# Altium schematic sheet 05)PSD1.SchDoc(05)PSD1)
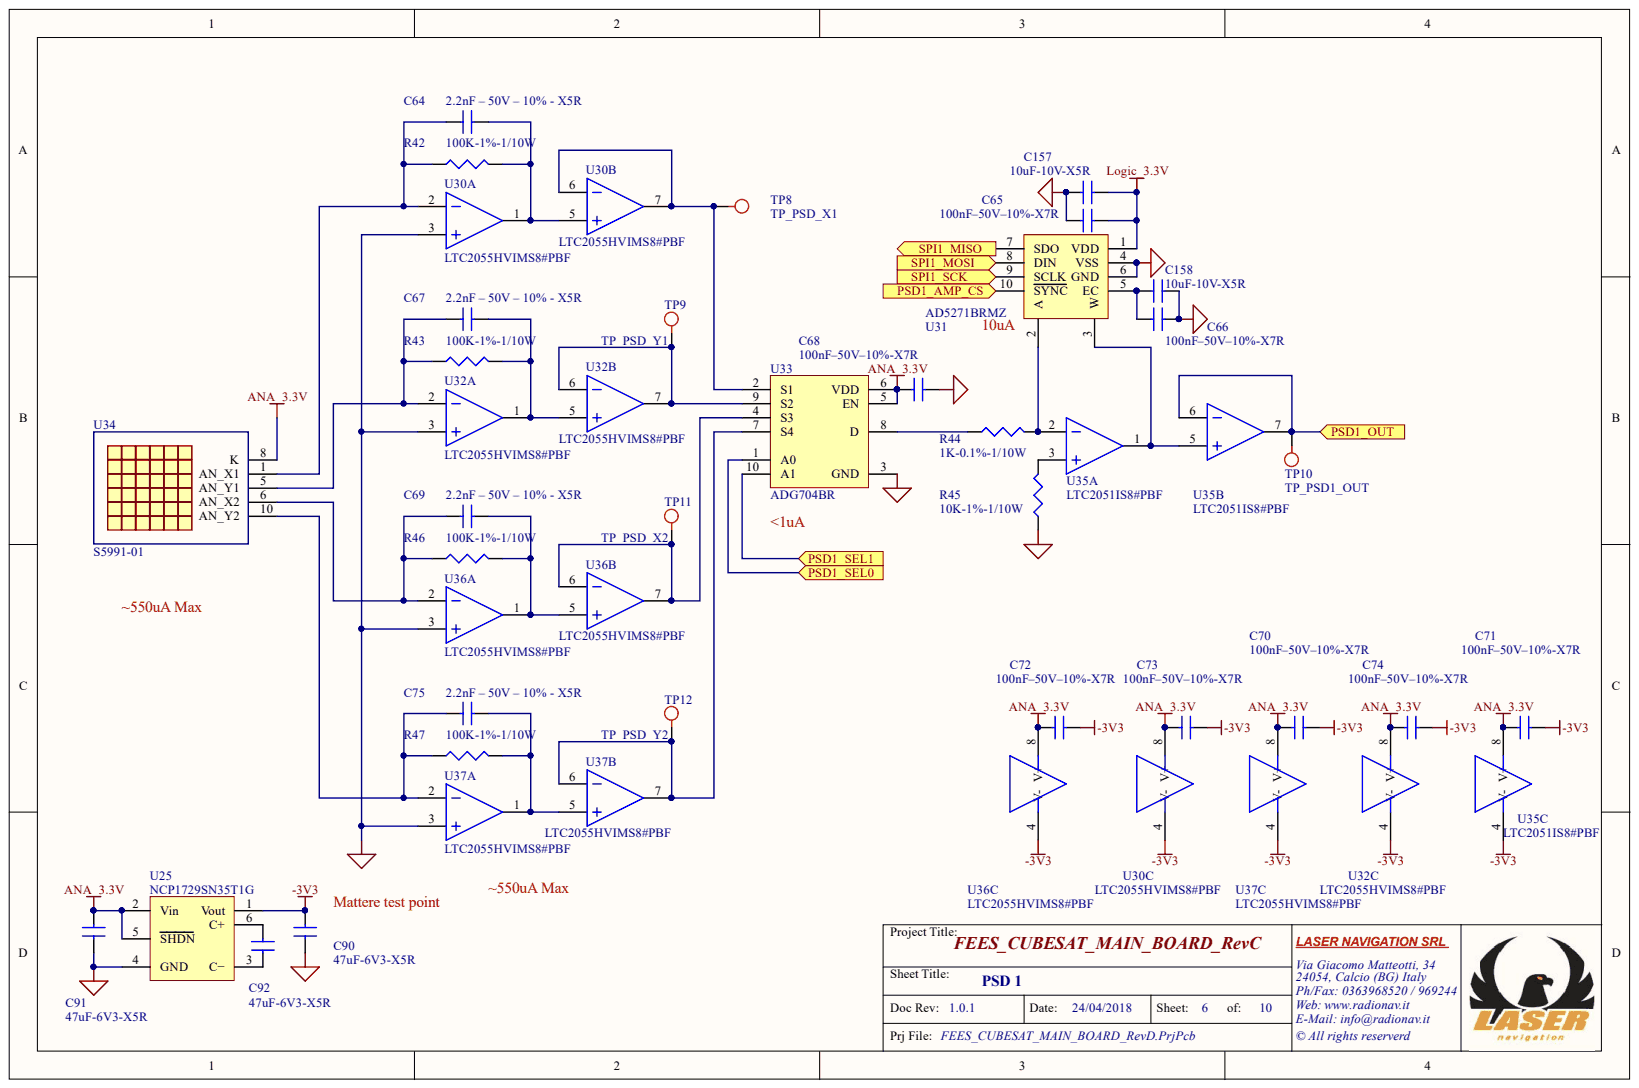
[diagram p0: 05)PSD1.SchDoc]

## Parts
C64  2.2nF – 50V – 10% - X5R  [0603_CAP]
C65  100nF–50V–10%-X7R  [0603_CAP]
C66  100nF–50V–10%-X7R  [0603_CAP]
C67  2.2nF – 50V – 10% - X5R  [0603_CAP]
C68  100nF–50V–10%-X7R  [0603_CAP]
C69  2.2nF – 50V – 10% - X5R  [0603_CAP]
C70  100nF–50V–10%-X7R  [0603_CAP]
C71  100nF–50V–10%-X7R  [0603_CAP]
C72  100nF–50V–10%-X7R  [0603_CAP]
C73  100nF–50V–10%-X7R  [0603_CAP]
C74  100nF–50V–10%-X7R  [0603_CAP]
C75  2.2nF – 50V – 10% - X5R  [0603_CAP]
C90  47uF-6V3-X5R  [0805_CAP]
C91  47uF-6V3-X5R  [0805_CAP]
C92  47uF-6V3-X5R  [0805_CAP]
C157  10uF-10V-X5R  [0805_CAP]
C158  10uF-10V-X5R  [0805_CAP]
R42  100K-1%-1/10W  [0603_RES]
R43  100K-1%-1/10W  [0603_RES]
R44  1K-0.1%-1/10W  [0603_RES]
R45  10K-1%-1/10W  [0603_RES]
R46  100K-1%-1/10W  [0603_RES]
R47  100K-1%-1/10W  [0603_RES]
TP8  TP_PSD_X1  [TP_SMD_PAD_1_5MM]
TP9  TP_PSD_Y1  [TP_SMD_PAD_1_5MM]
TP10  TP_PSD1_OUT  [TP_SMD_PAD_1_5MM]
TP11  TP_PSD_X2  [TP_SMD_PAD_1_5MM]
TP12  TP_PSD_Y2  [TP_SMD_PAD_1_5MM]
U25  NCP1729SN35T1G  [SOT23-6]
U30  LTC2055HVIMS8#PBF  [MSOP8]  (parts A,B,C)
U31  AD5271BRMZ  [MSOP10]
U32  LTC2055HVIMS8#PBF  [MSOP8]  (parts A,B,C)
U33  ADG704BR  [MSOP10_L]
U34  S5991-01  [S5991-01]
U35  LTC2051IS8#PBF  [MSOP8]  (parts A,B,C)
U36  LTC2055HVIMS8#PBF  [MSOP8]  (parts A,B,C)
U37  LTC2055HVIMS8#PBF  [MSOP8]  (parts A,B,C)

## Ports
PSD1_AMP_CS, PSD1_OUT, PSD1_SEL0, PSD1_SEL1, SPI1_MISO, SPI1_MOSI, SPI1_SCK

## Nets
-3V3: C70.2 C71.2 C72.2 C73.2 C74.2 C90.1 U25.1(Vout) U30.4(V-) U32.4(V-) U35.4(V-) U36.4(V-) U37.4(V-)
ANA_3.3V: C68.1 C70.1 C71.1 C72.1 C73.1 C74.1 C91.1 U25.2(Vin) U25.5(SHDN) U30.8(V+) U32.8(V+) U33.5(EN) U33.6(VDD) U34.8(K) U35.8(V+) U36.8(V+) U37.8(V+)
GND: C65.1 C66.1 C68.2 C90.2 C91.2 C157.2 C158.2 R45.1 U25.4(GND) U30.3 U31.4(VSS) U31.6(GND) U32.3 U33.3(GND) U36.3 U37.3
LOGIC_3.3V: C65.2 C157.1 U31.1(VDD)
PSD1_AMP_CS: U31.10(SYNC) ; port PSD1_AMP_CS
PSD1_OUT: TP10.1 U35.6 U35.7 ; port PSD1_OUT
PSD1_SEL0: U33.1(A0) ; port PSD1_SEL0
PSD1_SEL1: U33.10(A1) ; port PSD1_SEL1
SPI1_MISO: U31.7(SDO) ; port SPI1_MISO
SPI1_MOSI: U31.8(DIN) ; port SPI1_MOSI
SPI1_SCK: U31.9(SCLK) ; port SPI1_SCK
NetC64_1: C64.1 R42.1 U30.2 U34.1(AN_X1)
NetC64_2: C64.2 R42.2 U30.1 U30.5
NetC66_2: C66.2 C158.1 U31.5(EC)
NetC67_1: C67.1 R43.1 U32.2 U34.5(AN_Y1)
NetC67_2: C67.2 R43.2 U32.1 U32.5
NetC69_1: C69.1 R46.1 U34.6(AN_X2) U36.2
NetC69_2: C69.2 R46.2 U36.1 U36.5
NetC75_1: C75.1 R47.1 U34.10(AN_Y2) U37.2
NetC75_2: C75.2 R47.2 U37.1 U37.5
NetC92_1: C92.1 U25.6(C+)
NetC92_2: C92.2 U25.3(C−)
NetR44_1: R44.1 U33.8(D)
NetR44_2: R44.2 U31.2(A) U35.2
NetR45_2: R45.2 U35.3
NetTP8_1: TP8.1 U30.6 U30.7 U33.2(S1)
NetTP9_1: TP9.1 U32.6 U32.7 U33.9(S2)
NetTP11_1: TP11.1 U33.4(S3) U36.6 U36.7
NetTP12_1: TP12.1 U33.7(S4) U37.6 U37.7
NetU31_3: U31.3(W) U35.1 U35.5

## Library data of the parts
C64 C67 C69 C75 = CAP: Non polarized capacitor; 5.Mounting: Y; Component Kind: Standard; Library Name: User Sch Library.SchLib
C65 C66 C68 C70-C74 = CAP: Non polarized capacitor; Component Kind: Standard; Library Name: User Sch Library.SchLib
C90-C92 = CAP: Non polarized capacitor; 1.Part Number: JMK212BJ476MG-T; 2.Manufacturer: Taiyo Yuden; 3.Description: CAP CER 47UF 6.3V X5R 0805; 5.Mounting: Y; 4.Supplier: Digikey 587-1779-1-ND; Component Kind: Standard; Library Name: User Sch Library.SchLib
C157 C158 = CAP: Non polarized capacitor; 1.Part Number: LMK212BJ106KG-T; 2.Manufacturer: Taiyo Yuden; 3.Description: CAP CER 10UF 10V X5R 0805; 5.Mounting: Y; 4.Supplier: Digikey 587-1300-1-ND; Component Kind: Standard; Library Name: User Sch Library.SchLib
R42 R43 R45-R47 = R: Component Kind: Standard; Library Name: User Sch Library.SchLib
R44 = R: 1.Part Number: RT0603BRD071KL; 2.Manufacturer: Yageo; 3.Description: RES SMD 1K OHM 0.1% 1/10W 0603; 5.Mounting: Y; 4.Supplier: Digikey YAG1237CT-ND; Component Kind: Standard; Library Name: User Sch Library.SchLib
TP8-TP12 = TP: Test Point; Component Kind: Standard; Library Name: User Sch Library.SchLib
U25 = NCP1729: Operational Amplifier; 2.Manufacturer: ON Semiconductor; 3.Description: IC REG SWTCHD CAP INV 50MA 6TSOP; 5.Mounting: Y; 1.Part Number: NCP1729SN35T1G; 4.Supplier: Digikey  NCP1729SN35T1GOSCT-ND; Component Kind: Standard; Library Name: User Sch Library.SchLib
U30 U32 U36 U37 = LTC2055: Low power dual operational amplifiers; 2.Manufacturer: Linear Technology; 3.Description: IC OPAMP CHOPPER 500KHZ 8MSOP; 5.Mounting: Y; 1.Part Number: LTC2055HVIMS8#PBF; 4.Supplier: RS  851-6837 // Digikey LTC2055HVIMS8#PBF-ND; Component Kind: Standard; Library Name: User Sch Library.SchLib
U31 = AD5271BRMZ: Digital Potentiometer Circuit 256 Taps SPI Interface; 1.Part Number: AD5271BRMZ-100; 2.Manufacturer: Analog Devices Inc.; 3.Description: IC RHEOSTAT 5V 50-TP 256 10MSOP; 5.Mounting: Y; 4.Supplier: Digikey  	AD5271BRMZ-100-ND; Component Kind: Standard; Library Name: User Sch Library.SchLib
U33 = ADG704BR: 4ch ADC I2C; 1.Part Number: ADG704BRMZ; 2.Manufacturer: Analog Devices Inc.; 3.Description: IC MULTIPLEXER 4X1 10USOIC; 5.Mounting: Y; 4.Supplier: Digikey  ADG704BRMZ-ND; Component Kind: Standard; Library Name: User Sch Library.SchLib
U34 = S5991-01: 4ch ADC I2C; 2.Manufacturer: Hamamatsu; 3.Description: Two dimensional PSD; 5.Mounting: Y; 1.Part Number: S5991-01; 4.Supplier: Hamamatsu; Component Kind: Standard; Library Name: User Sch Library.SchLib
U35 = LTC2055: Low power dual operational amplifiers; 1.Part Number: LTC2051HVHMS8#PBF; 2.Manufacturer: Linear Technology; 3.Description: IC OPAMP CHOPPER 3MHZ RRO 8MSOP; 5.Mounting: Y; 4.Supplier: Digikey LTC2051HVHMS8#PBF-ND; Component Kind: Standard; Library Name: User Sch Library.SchLib
